# Altium schematic sheet RPi-Headers.SchDoc(RPi-Headers)
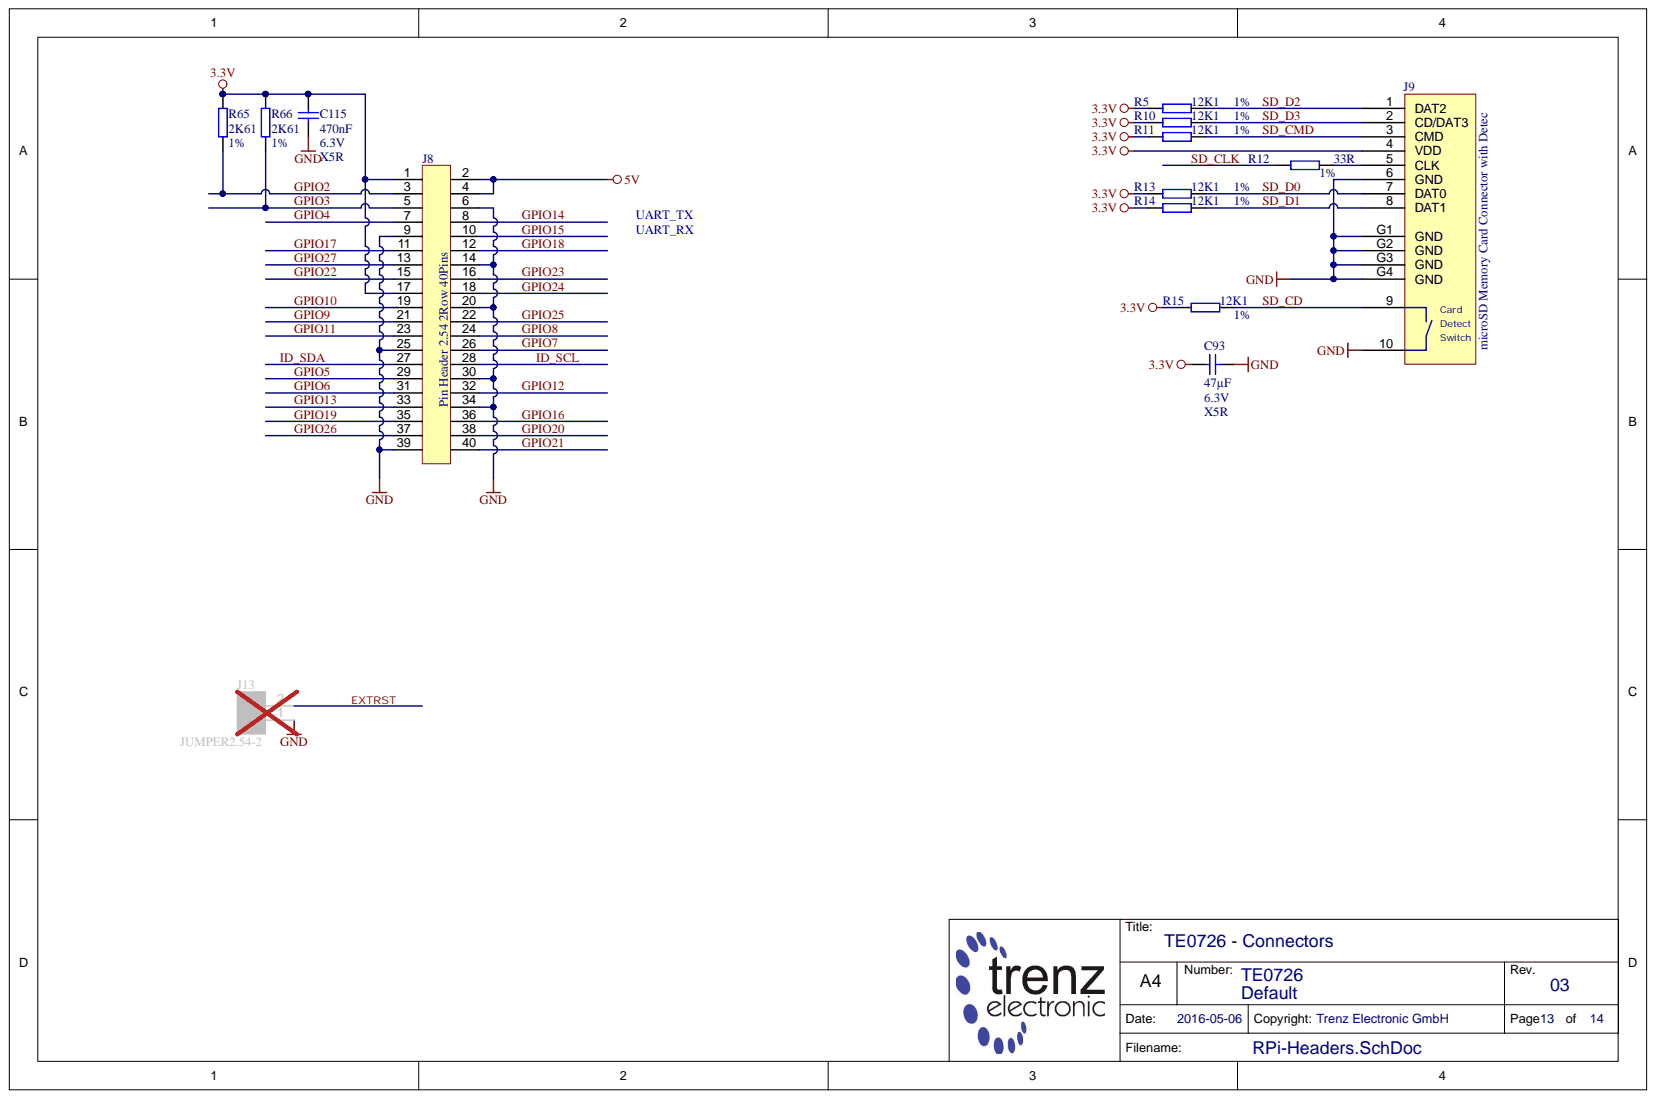
[diagram p0: RPi-Headers.SchDoc]

## Parts
C93  47µF  Voltage: 6.3V  [CAPC2012X135N]
C115  470nF  Technology: X5R  Voltage: 6.3V  [CAPC0603X33N]
J8  Pin Header 2.54 2Row 40Pins  [STIFTLEISTE2_54 OG 2R-40]
J9  microSD Memory Card Connector with Detec  Case: microSD  [104031-0811]
J13  JUMPER2.54-2  [JUMPER2_54-2]
R5  12K1  [RESC1005X40N]
R10  12K1  [RESC1005X40N]
R11  12K1  [RESC1005X40N]
R12  33R  Tolerance: 1%  [RESC0603X26N]
R13  12K1  [RESC1005X40N]
R14  12K1  [RESC1005X40N]
R15  12K1  Tolerance: 1%  [RESC1005X40N]
R65  2K61  Tolerance: 1%  [RESC1005X40N]
R66  2K61  Tolerance: 1%  [RESC1005X40N]

## Nets
3.3V: C93.1 C115.1 J8.1 J8.17 J9.4(VDD) R5.2 R10.2 R11.2 R13.2 R14.2 R15.2 R65.2 R66.2
5V: J8.2 J8.4
GND: C93.2 C115.2 J8.6 J8.9 J8.14 J8.20 J8.25 J8.30 J8.34 J8.39 J9.6(GND) J9.10 J9.G1(GND) J9.G2(GND) J9.G3(GND) J9.G4(GND) J13.1
EXTRST: J13.2
GPIO2: J8.3 R65.1
GPIO3: J8.5 R66.1
GPIO4: J8.7
GPIO5: J8.29
GPIO6: J8.31
GPIO7: J8.26
GPIO8: J8.24
GPIO9: J8.21
GPIO10: J8.19
GPIO11: J8.23
GPIO12: J8.32
GPIO13: J8.33
GPIO14: J8.8
GPIO15: J8.10
GPIO16: J8.36
GPIO17: J8.11
GPIO18: J8.12
GPIO19: J8.35
GPIO20: J8.38
GPIO21: J8.40
GPIO22: J8.15
GPIO23: J8.16
GPIO24: J8.18
GPIO25: J8.22
GPIO26: J8.37
GPIO27: J8.13
ID_SCL: J8.28
ID_SDA: J8.27
SD_CD: J9.9 R15.1
SD_CLK: R12.1
SD_CMD: J9.3(CMD) R11.1
SD_D0: J9.7(DAT0) R13.1
SD_D1: J9.8(DAT1) R14.1
SD_D2: J9.1(DAT2) R5.1
SD_D3: J9.2(CD/DAT3) R10.1
NetJ9_5: J9.5(CLK) R12.2

## Library data of the parts
C93 = 24718: KON 47µF 6,3V  X5R SMD 20%; Database Table Name: C_2012_0805; Mixed: SMT; Preferred: Yes; Value: 47u; AN: 24718; Case: 2012 (0805); Component Kind: Standard; Library Name: TE.DbLib; Technology: X5R; Tolerance: 20%
C115 = 24921: KON 470nF X5R 6.3V SMD 20%; Database Table Name: C_0603_0201; Mixed: SMT; Preferred: Yes; Value: 470n; AN: 24921; Case: 0603 (0201); Component Kind: Standard; Library Name: TE.DbLib; Tolerance: 20%
J8 = 22320: Stiftleiste gerade 2-reihig, 40pol; Database Table Name: CON_HEADER; Mixed: THT; Trenz-Stock: Yes; AN: 22320; Component Kind: Standard; Library Name: TE.DbLib
J9 = 26322: microSD Memory Card Connector with Detect Switch; Database Table Name: SOCKET; Mixed: SMT; Trenz-Stock: Yes; AN: 26322; Component Kind: Standard; H-ART: 104031-0811; Library Name: TE.DbLib; HelpURL: B:\AD\adlib\datasheet\104031-0811
J13 = 27212: Stiftleiste gerade 1-reihig, 2pol.; Database Table Name: CON_HEADER; Mixed: THT; Trenz-Stock: Yes; AN: 27212; Component Kind: Standard; Library Name: TE.DbLib
R5 R10 R11 R13-R15 = 22849: WID SMD 12K1 1% 0,063W; Database Table Name: R_1005_0402; Mixed: SMT; Preferred: Yes; Value: 12.1K; AN: 22849; Case: 1005 (0402); Component Kind: Standard; Library Name: TE.DbLib; Technology: TK100
  R5 R10 R11 R13 R14: Tolerance: 1%
R12 = 25021: WID SMD 33R 1% 0,05W; Database Table Name: R_0603_0201; Mixed: SMT; Preferred: Yes; Value: 33; AN: 25021; Case: 0603 (0201); Component Kind: Standard; Library Name: TE.DbLib; Technology: TK100; HelpURL: B:\AD\adlib\datasheet\R0201
R65 R66 = 23730: WID SMD 2K61 1% 0,063W; Database Table Name: R_1005_0402; Mixed: SMT; Preferred: Yes; Value: 2.61K; AN: 23730; Case: 1005 (0402); Component Kind: Standard; Library Name: TE.DbLib; Technology: TK100
